# Altium schematic sheet Sheet1.SchDoc
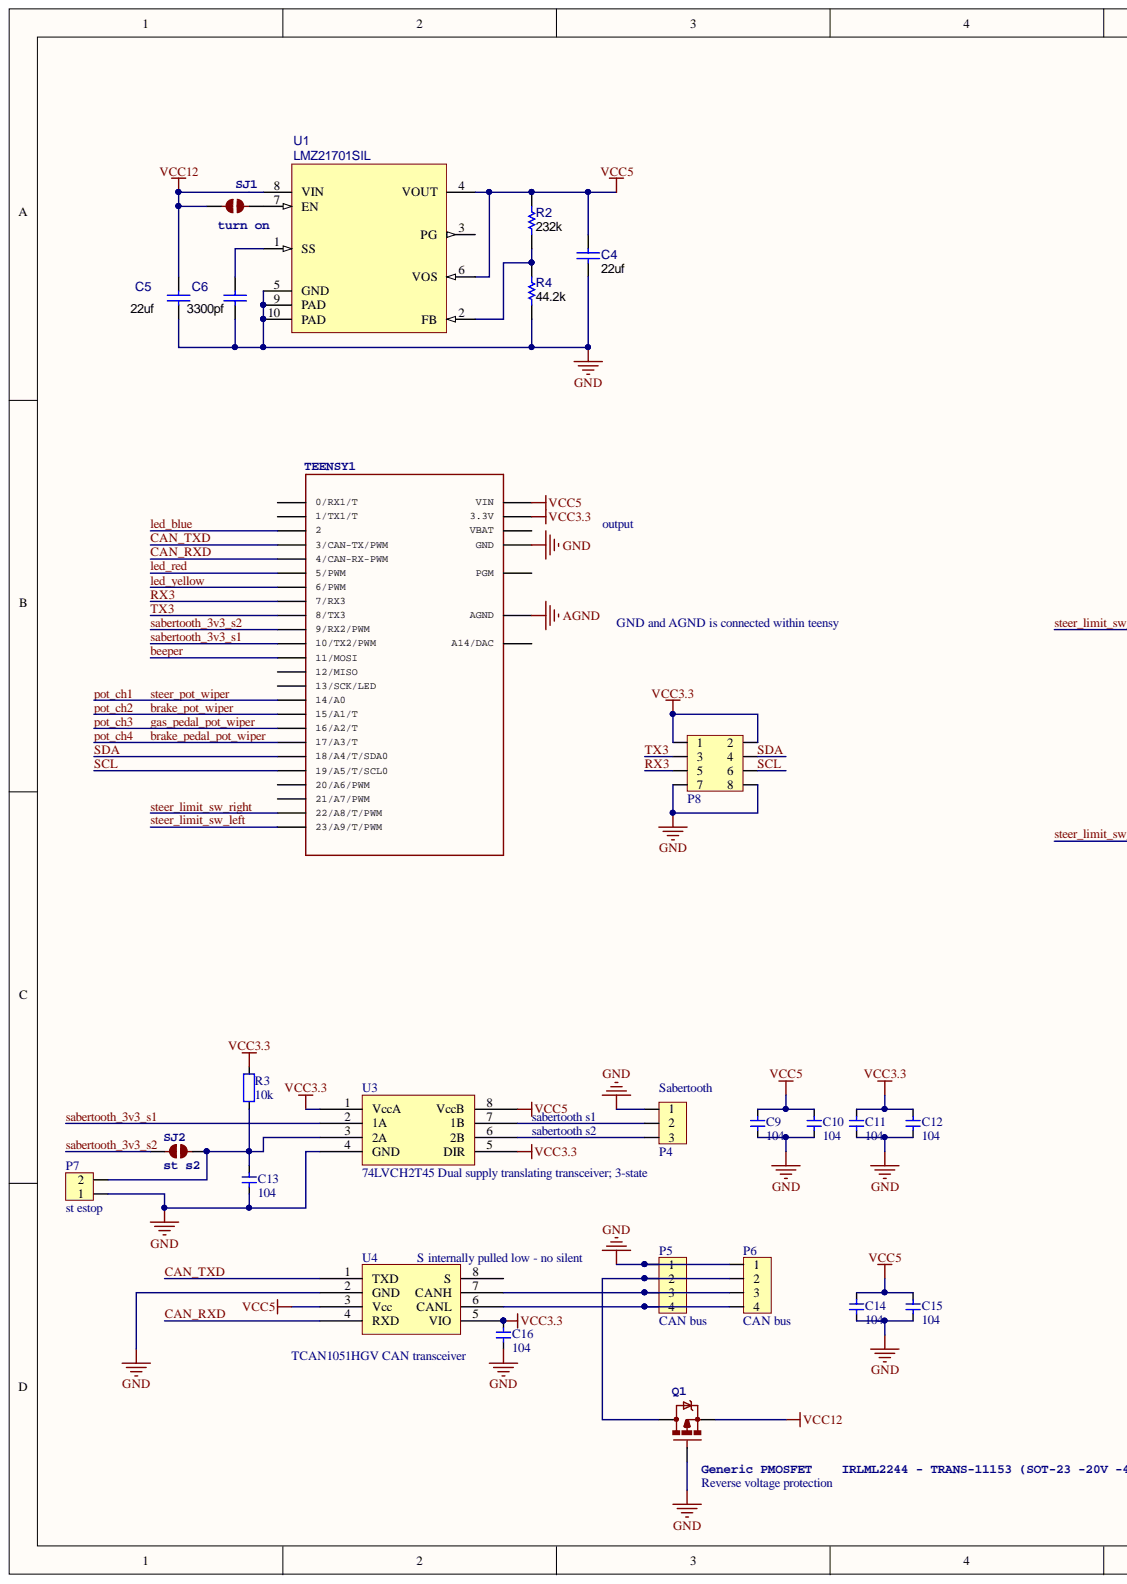
[diagram p0: Sheet1.SchDoc, left]
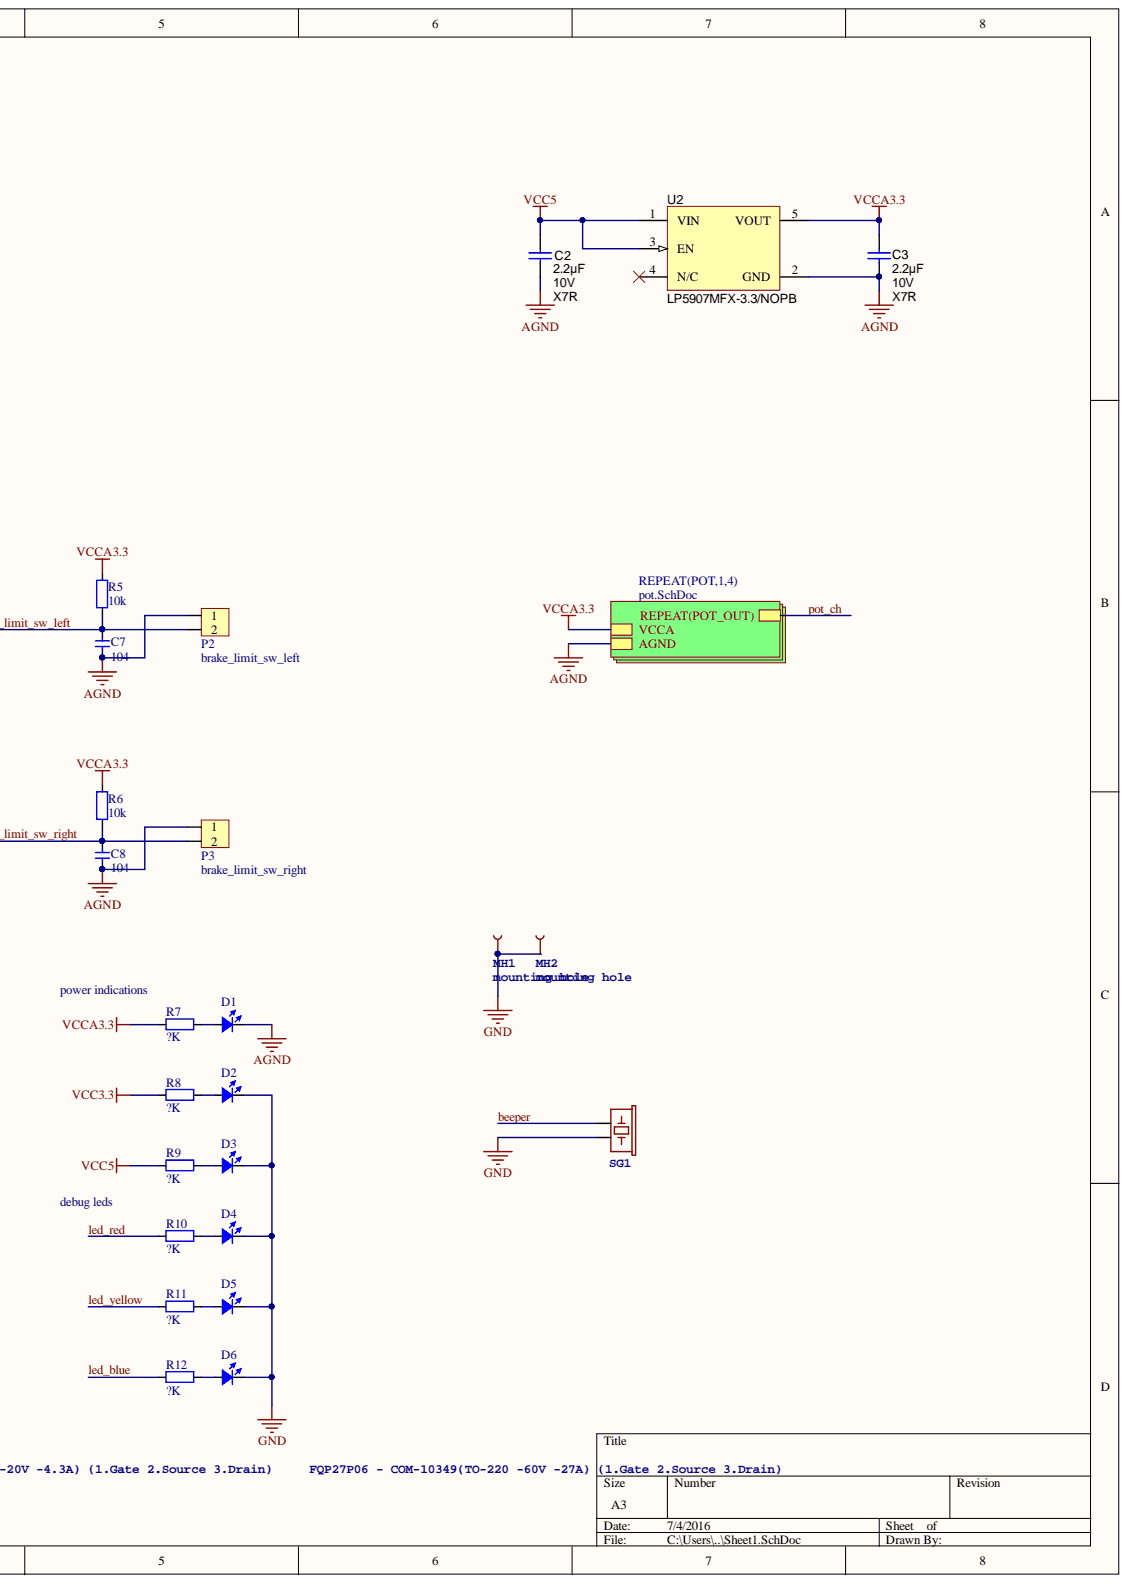
[diagram p1: Sheet1.SchDoc, right]

## Parts
C2  TempCo: X7R  Value2: 10V  ValueDisplayed: 2.2µF  [CAP_0603]  (hidden comment: GRM188R71A225KE15D)
C3  TempCo: X7R  Value2: 10V  ValueDisplayed: 2.2µF  [CAP_0603]  (hidden comment: GRM188R71A225KE15D)
C4  ValueDisplayed: 22uf  [CAP_0805]  (hidden comment: GRM188R71A225KE15D)
C5  ValueDisplayed: 22uf  [CAP_0805]  (hidden comment: GRM155R71E822KA01D)
C6  ValueDisplayed: 3300pf  [CAP_0603]  (hidden comment: GRM155R71E822KA01D)
C7  value 104  [CAP_0603]  (hidden comment: Cap_0603)
C8  value 104  [CAP_0603]  (hidden comment: Cap_0603)
C9  value 104  [CAP_0603]  (hidden comment: Cap_0603)
C10  value 104  [CAP_0603]  (hidden comment: Cap_0603)
C11  value 104  [CAP_0603]  (hidden comment: Cap_0603)
C12  value 104  [CAP_0603]  (hidden comment: Cap_0603)
C13  value 104  [CAP_0603]  (hidden comment: Cap_0603)
C14  value 104  [CAP_0603]  (hidden comment: Cap_0603)
C15  value 104  [CAP_0603]  (hidden comment: Cap_0603)
C16  value 104  [CAP_0603]  (hidden comment: Cap_0603)
D1  LED_0603  [LED_0603_RED]  (value not drawn)
D2  LED_0603  [LED_0603_RED]  (value not drawn)
D3  LED_0603  [LED_0603_RED]  (value not drawn)
D4  LED_0603  [LED_0603_RED]  (value not drawn)
D5  LED_0603  [LED_0603_RED]  (value not drawn)
D6  LED_0603  [LED_0603_RED]  (value not drawn)
MH1  mounting hole  [M3 hole]
MH2  mounting hole  [M3 hole]
P2  brake_limit_sw_left  [COMBICON PTSA 2]
P3  brake_limit_sw_right  [COMBICON PTSA 2]
P4  Sabertooth  [COMBICON PTSA 3]
P5  CAN bus  [COMBICON PTSA 4]
P6  CAN bus  [COMBICON PTSA 4]
P7  st estop  [COMBICON PTSA 2]
P8  HDR2x4_2.54  [HDR2X4_2.54_90]  (value not drawn)
Q1  Generic PMOSFET    IRLML2244 - TRANS-11153 (SOT-23 -20V -4.3A) (1.Gate 2.Source 3.Drain)     FQP27P06 - COM-10349(TO-220 -60V -27A) (1.Gate 2.Source 3.Drain)  [SOT23-3]  (value not drawn)
R2  value 232k  [RES_0603]  (hidden comment: CRCW040235K7FKED)
R3  value 10k  [RES_0603]  (hidden comment: Res_0603)
R4  value 44.2k  [RES_0603]  (hidden comment: CRCW04026K81FKED)
R5  value 10k  [RES_0603]  (hidden comment: Res_0603)
R6  value 10k  [RES_0603]  (hidden comment: Res_0603)
R7  value ?K  [RES_0603]  (hidden comment: Res_0603)
R8  value ?K  [RES_0603]  (hidden comment: Res_0603)
R9  value ?K  [RES_0603]  (hidden comment: Res_0603)
R10  value ?K  [RES_0603]  (hidden comment: Res_0603)
R11  value ?K  [RES_0603]  (hidden comment: Res_0603)
R12  value ?K  [RES_0603]  (hidden comment: Res_0603)
SG1  Buzzer 12mmSpark Fun Electronics SKU : Comp-Buzzer  [Buzzer_5x5]  (value not drawn)
SJ1  turn on  [HDR1X2_2.54_180]
SJ2  st s2  [PAD-JUMPER-2-NO_YES_SILK]
TEENSY1  Footprint for Teensy 3.1 board using all pin connections on the outer perimeter  [TEENSY_3.1_BASIC_FIXED]  (value not drawn)
U1  LMZ21701SIL  [LMZ21701SIL]
U2  LP5907MFX-3.3/NOPB  [DBV0005A_N]
U3  74LVCH2T45 Dual supply translating transceiver; 3-state  [SOP65P490X110-8N]
U4  TCAN1051HGV CAN transceiver  [SOIC_E200_8]

## Nets
AGND: C2.1 C3.1 C7.1 C8.1 D1.2 P2.1 P3.1 TEENSY1.AGND U2.2(GND)
GND: C4.2 C5.2 C6.2 C9.1 C10.1 C11.1 C12.1 C13.1 C14.1 C15.1 C16.1 D2.2 D3.2 D4.2 D5.2 D6.2 MH1.P$1 MH2.P$1 P4.1 P5.1 P6.1 P7.1 P8.7 P8.8 Q1.1 R4.1 SG1.- TEENSY1.GND GND1(GND) U1.5(GND) U1.9(PAD) U1.10(PAD) U3.4(GND) U4.2(GND)
VCC3.3: C11.2 C12.2 C16.2 P8.1 P8.2 R3.2 R8.1 TEENSY1.3.3V 3.3V1(3.3V) U3.1(VccA) U3.5(DIR) U4.5(VIO)
VCC5: C2.2 C4.1 C9.2 C10.2 C14.2 C15.2 R2.2 R9.1 TEENSY1.VIN U1.4(VOUT) U1.6(VOS) U2.1(VIN) U2.3(EN) U3.8(VccB) U4.3(Vcc)
VCC12: C5.1 Q1.2 SJ1.1 U1.8(VIN)
VCCA3.3: C3.2 R5.2 R6.2 R7.1 U2.5(VOUT)
BEEPER: SG1.+ TEENSY1.11(11/MOSI)
BRAKE_PEDAL_POT_WIPER: TEENSY1.17/A3(17/A3/T)
BRAKE_POT_WIPER: TEENSY1.15/A1(15/A1/T)
CAN_RXD: TEENSY1.4(4/CAN-RX-PWM) U4.4(RXD)
CAN_TXD: TEENSY1.3(3/CAN-TX/PWM) U4.1(TXD)
GAS_PEDAL_POT_WIPER: TEENSY1.16/A2(16/A2/T)
LED_BLUE: R12.1 TEENSY1.2
LED_RED: R10.1 TEENSY1.5(5/PWM)
LED_YELLOW: R11.1 TEENSY1.6(6/PWM)
POT_CH1: TEENSY1.14/A0
RX3: P8.5 TEENSY1.7(7/RX3)
SABERTOOTH_3V3_S1: TEENSY1.10(10/TX2/PWM) U3.2(1A)
SABERTOOTH_3V3_S2: SJ2.1 TEENSY1.9(9/RX2/PWM)
SCL: P8.6 TEENSY1.19/A5(19/A5/T/SCL0)
SDA: P8.4 TEENSY1.18/A4(18/A4/T/SDA0)
STEER_LIMIT_SW_LEFT: C7.2 P2.2 R5.1 TEENSY1.23/A9(23/A9/T/PWM)
STEER_LIMIT_SW_RIGHT: C8.2 P3.2 R6.1 TEENSY1.22/A8(22/A8/T/PWM)
TX3: P8.3 TEENSY1.8(8/TX3)
NetC6_1: C6.1 U1.1(SS)
NetC13_2: C13.2 P7.2 R3.1 SJ2.2 U3.3(2A)
NetD1_1: D1.1 R7.2
NetD2_1: D2.1 R8.2
NetD3_1: D3.1 R9.2
NetD4_1: D4.1 R10.2
NetD5_1: D5.1 R11.2
NetD6_1: D6.1 R12.2
NetP4_2: P4.2 U3.7(1B)
NetP4_3: P4.3 U3.6(2B)
NetP5_2: P5.2 P6.2 Q1.3
NetP5_3: P5.3 P6.3 U4.7(CANH)
NetP5_4: P5.4 P6.4 U4.6(CANL)
NetR2_1: R2.1 R4.2 U1.2(FB)
NetSJ1_2: SJ1.2 U1.7(EN)
NetTEENSY1_0: TEENSY1.0(0/RX1/T)
NetTEENSY1_1: TEENSY1.1(1/TX1/T)
NetTEENSY1_12: TEENSY1.12(12/MISO)
NetTEENSY1_13: TEENSY1.13(13/SCK/LED)
NetTEENSY1_20/A6: TEENSY1.20/A6(20/A6/PWM)
NetTEENSY1_21/A7: TEENSY1.21/A7(21/A7/PWM)
NetTEENSY1_A14: TEENSY1.A14(A14/DAC)
NetTEENSY1_PGM: TEENSY1.PGM
NetTEENSY1_VBAT: TEENSY1.VBAT
NetU1_3: U1.3(PG)
NetU2_4: U2.4(N/C)
NetU4_8: U4.8(S)

## Library data of the parts
C2 C4 = GRM188R71A225KE15D: CAP, CERM, 2.2uF, 10V, +/-10%, X7R, 0603; Manufacturer: MuRata; Cap_Case: 0603; Cap_F: 0.0000022; CompType: Capacitor; ESR_Ohm: 0.009; Polarized: N; TaMax_C: 125; TaMin_C: -55; Tolerance_%: 10; U/M: EA; Value1: 0.009 ohm; Component Kind: Standard; ComponentLink1Description: Datasheet link; ComponentLink1URL: http://search.murata.co.jp/Ceramy/PnsearchViewAction.do?HnOFG=ON&HnNFG=ON&SEL_CNT=50&sLang=en&sKey=GRM188R71A225KE15D&x=9&y=9&search=startwith; Library Name: Capacitor_Ceramic.IntLib; MountType: SMT; PackageReference: 0603; PartNumber: GRM188R71A225KE15D; Published: 2008-06-19; RoHS: Y; Signal Integrity: Cap; Simulation: Cap; Supplier 1: Digi-Key; Supplier 2: Mouser; Supplier 3: Farnell; Supplier Part Number 1: 490-4520-1-ND; Supplier Part Number 2: 81-GRM188R71A225KE15; Supplier Part Number 3: 1797012; SymbolVersion: 2008-07-09; Technology: Ceramic; Value: 2.2uF; VDC_V: 10; Publisher: Texas Instruments
  C2: LatestRevisionDate: 2014-07-08
  C4: LatestRevisionDate: 2014-06-17; TempCo: X7R; Value2: 10V
C3 = GRM188R71A225KE15D: CAP, CERM, 2.2 µF, 10 V, +/- 10%, X7R, 0603; Manufacturer: MuRata; Cap_Case: 0603; Cap_F: 0.0000022; CompType: Capacitor; ESR_ohm: 0.009; Polarized: N; TaMax_C: 125; TaMin_C: -55; Tolerance_%: 10; U/M: EA; Value: 2.2uF; Value1: 0.009 ohm; Component Kind: Standard; ComponentLink1Description: Datasheet link; ComponentLink1URL: http://search.murata.co.jp/Ceramy/PnsearchViewAction.do?HnOFG=ON&HnNFG=ON&SEL_CNT=50&sLang=en&sKey=GRM188R71A225KE15D&x=9&y=9&search=startwith; LatestRevisionDate: 2014-07-21; Library Name: Capacitor_Ceramic.IntLib; MountType: SMT; PackageReference: 0603; PartNumber: GRM188R71A225KE15D; Published: 2008-06-19; RoHS: Y; Signal Integrity: Cap; Simulation: Cap; Supplier 1: Digi-Key; Supplier 2: Mouser; Supplier 3: Farnell; Supplier Part Number 1: 490-4520-1-ND; Supplier Part Number 2: 81-GRM188R71A225KE15; Supplier Part Number 3: 1797012; SymbolVersion: 2008-07-09; Technology: Ceramic; VDC_V: 10; Publisher: Texas Instruments
C5 C6 = GRM155R71E822KA01D: CAP, CERM, 8200pF, 25V, +/-10%, X7R, 0402; Manufacturer: MuRata; Cap_Case: 0402; Cap_F: 0.0000000082; CompType: Capacitor; Polarized: N; TaMax_C: 125; TaMin_C: -55; Tolerance_%: 10; U/M: EA; Value1: Unknown; VDC_V: 25; Component Kind: Standard; ComponentLink1Description: Datasheet link; ComponentLink1URL: http://search.murata.co.jp/Ceramy/PnsearchViewAction.do?HnOFG=ON&HnNFG=ON&SEL_CNT=50&sLang=en&sKey=GRM155R71E822KA01D&x=9&y=9&search=startwith; LatestRevisionDate: 2014-07-07; Library Name: Capacitor_Ceramic.IntLib; MountType: SMT; PackageReference: 0402; PartNumber: GRM155R71E822KA01D; Published: 2008-06-19; RoHS: Y; Signal Integrity: Cap; Simulation: Cap; Supplier 1: Digi-Key; Supplier 2: Mouser; Supplier Part Number 1: 490-1311-1-ND; Supplier Part Number 2: 81-GRM155R71E822KA1D; SymbolVersion: 2008-07-09; Technology: Ceramic; TempCo: X7R; Value: 8200pF; Value2: 25V; Publisher: Texas Instruments
C7-C16 = Cap_0603: Capacitor; Component Kind: Standard; Library Name: SCH_Passive.SchLib
D1-D6 = LED_0603: LED; Component Kind: Standard; Library Name: SCH_Active.SchLib
MH1 MH2 = TEST-POINT2: Component Kind: Standard; Library Name: SparkFun-Passives.IntLib
P2 P3 P7 = HDR1x2_2.54: Header; Component Kind: Standard; Library Name: SCH_ConnectorHDR.SchLib
P4 = HDR1x3_2.54: Header; Component Kind: Standard; Library Name: SCH_ConnectorHDR.SchLib
P5 P6 = HDR1x4_2.54: Header; Component Kind: Standard; Library Name: SCH_ConnectorHDR.SchLib
P8 = HDR2x4_2.54: Header; Component Kind: Standard; Library Name: SCH_ConnectorHDR.SchLib
Q1 = MOSFET-PCHANNEL: PROD_ID: TRANS-08285; Component Kind: Standard; Library Name: SparkFun-DiscreteSemi.IntLib
R2 = CRCW040235K7FKED: RES, 35.7k ohm, 1%, 0.063W, 0402; Manufacturer: Vishay-Dale; CompType: Resistor; EIA_Code: E96; Power_W: 0.063; Res_ohm: 35700; TempCo: 100 ppm/C; Tolerance_%: 1; U/M: EA; Value_Code: 35k7; VDC_V: 50; Component Kind: Standard; ComponentLink1Description: Datasheet link; ComponentLink1URL: http://www.vishay.com/docs/20035/dcrcwe3.pdf; LatestRevisionDate: 2014-06-26; Library Name: Resistor_0402.IntLib; MountType: SMT; PackageReference: 0402; PartNumber: CRCW040235K7FKED; Published: 2008-06-26; RoHS: Y; Signal Integrity: Resistor; Simulation: Resistor; Supplier 1: Digi-Key; Supplier 2: Mouser; Supplier 3: Farnell; Supplier Part Number 1: 541-35.7KLCT-ND; Supplier Part Number 2: 71-CRCW0402-35.7K-E3; Supplier Part Number 3: 1151195; SymbolVersion: 2009-05-29; Technology: Thick Film; Value1: 1%; Value2: 0.063W; Publisher: Texas Instruments
R3 R5-R12 = Res_0603: Resistor; Component Kind: Standard; Library Name: SCH_Passive.SchLib
R4 = CRCW04026K81FKED: RES, 6.81k ohm, 1%, 0.063W, 0402; Manufacturer: Vishay-Dale; CompType: Resistor; EIA_Code: E96; Power_W: 0.063; Res_ohm: 6810; TempCo: 100 ppm/C; Tolerance_%: 1; U/M: EA; Value_Code: 6k81; VDC_V: 50; Component Kind: Standard; ComponentLink1Description: Datasheet link; ComponentLink1URL: http://www.vishay.com/docs/20035/dcrcwe3.pdf; LatestRevisionDate: 2014-06-26; Library Name: Resistor_0402.IntLib; MountType: SMT; PackageReference: 0402; PartNumber: CRCW04026K81FKED; Published: 2008-06-26; RoHS: Y; Signal Integrity: Resistor; Simulation: Resistor; Supplier 1: Digi-Key; Supplier 2: Mouser; Supplier 3: Farnell; Supplier Part Number 1: 541-6.81KLCT-ND; Supplier Part Number 2: 71-CRCW0402-6.81K-E3; Supplier Part Number 3: 1652819; SymbolVersion: 2009-05-29; Technology: Thick Film; Value1: 1%; Value2: 0.063W; Publisher: Texas Instruments
SG1 = BUZZERPTH: Component Kind: Standard; Library Name: SparkFun-Electromechanical.IntLib
SJ1 SJ2 = JUMPER-PAD-2-NOROUND: Component Kind: Standard; Library Name: SparkFun-Passives.IntLib
TEENSY1 = TEENSY_3.1_BASIC: Component Kind: Standard; Library Name: Teensy_3_Series_Board_v1.0.IntLib
U1 = LMZ21701SIL: 1A SIMPLE SWITCHER Nano Module with 17V Maximum Input Voltage, SIL0008E; Alternate Manufacturer: None; Manufacturer: Texas Instruments; CompType: IC; SymbolCreator: a0411947; U/M: EA; Component Kind: Standard; ComponentLink1Description: Datasheet Link; ComponentLink1URL: https://sps06.itg.ti.com/sites/KMS/crossdivteams/altium/docs/ComponentDatasheets/LMZ21701_DS_2013_07_10.pdf; LatestRevisionDate: 2014-08-23; MountType: SMT; PackageReference: SIL0008E; PartNumber: LMZ21701SIL; RoHS: Y; SymbolVersion: 2013-08-23; Library Name: TI_Pre_RTM.IntLib; Publisher: Texas Instruments
U2 = LP5907MFX-3.3/NOPB: ULTRA LOW-NOISE, 250-mA LINEAR REGULATOR FOR RF AND ANALOG CIRCUITS REQUIRES NO BYPASS CAPACITOR, DBV0005A; Alternate Manufacturer: None; Manufacturer: Texas Instruments; CompType: IC; SymbolCreator: a0799628; U/M: EA; Component Kind: Standard; ComponentLink1Description: Datasheet Link; ComponentLink1URL: http://www.ti.com/lit/ds/symlink/lp5907.pdf; LatestRevisionDate: 2014-07-28; MountType: SMT; PackageReference: DBV0005A; PartNumber: LP5907MFX-3.3/NOPB; RoHS: Y; SymbolVersion: 2014-03-21; Library Name: ISE4022_TIDA-00314.IntLib; Publisher: Texas Instruments
U3 = 74LVCH2T45: Component Kind: Standard; Library Name: robocart.SchLib
U4 = TCAN1051HGV: Component Kind: Standard; Library Name: robocart.SchLib
